# Altium schematic sheet PERIPHERAL.SchDoc
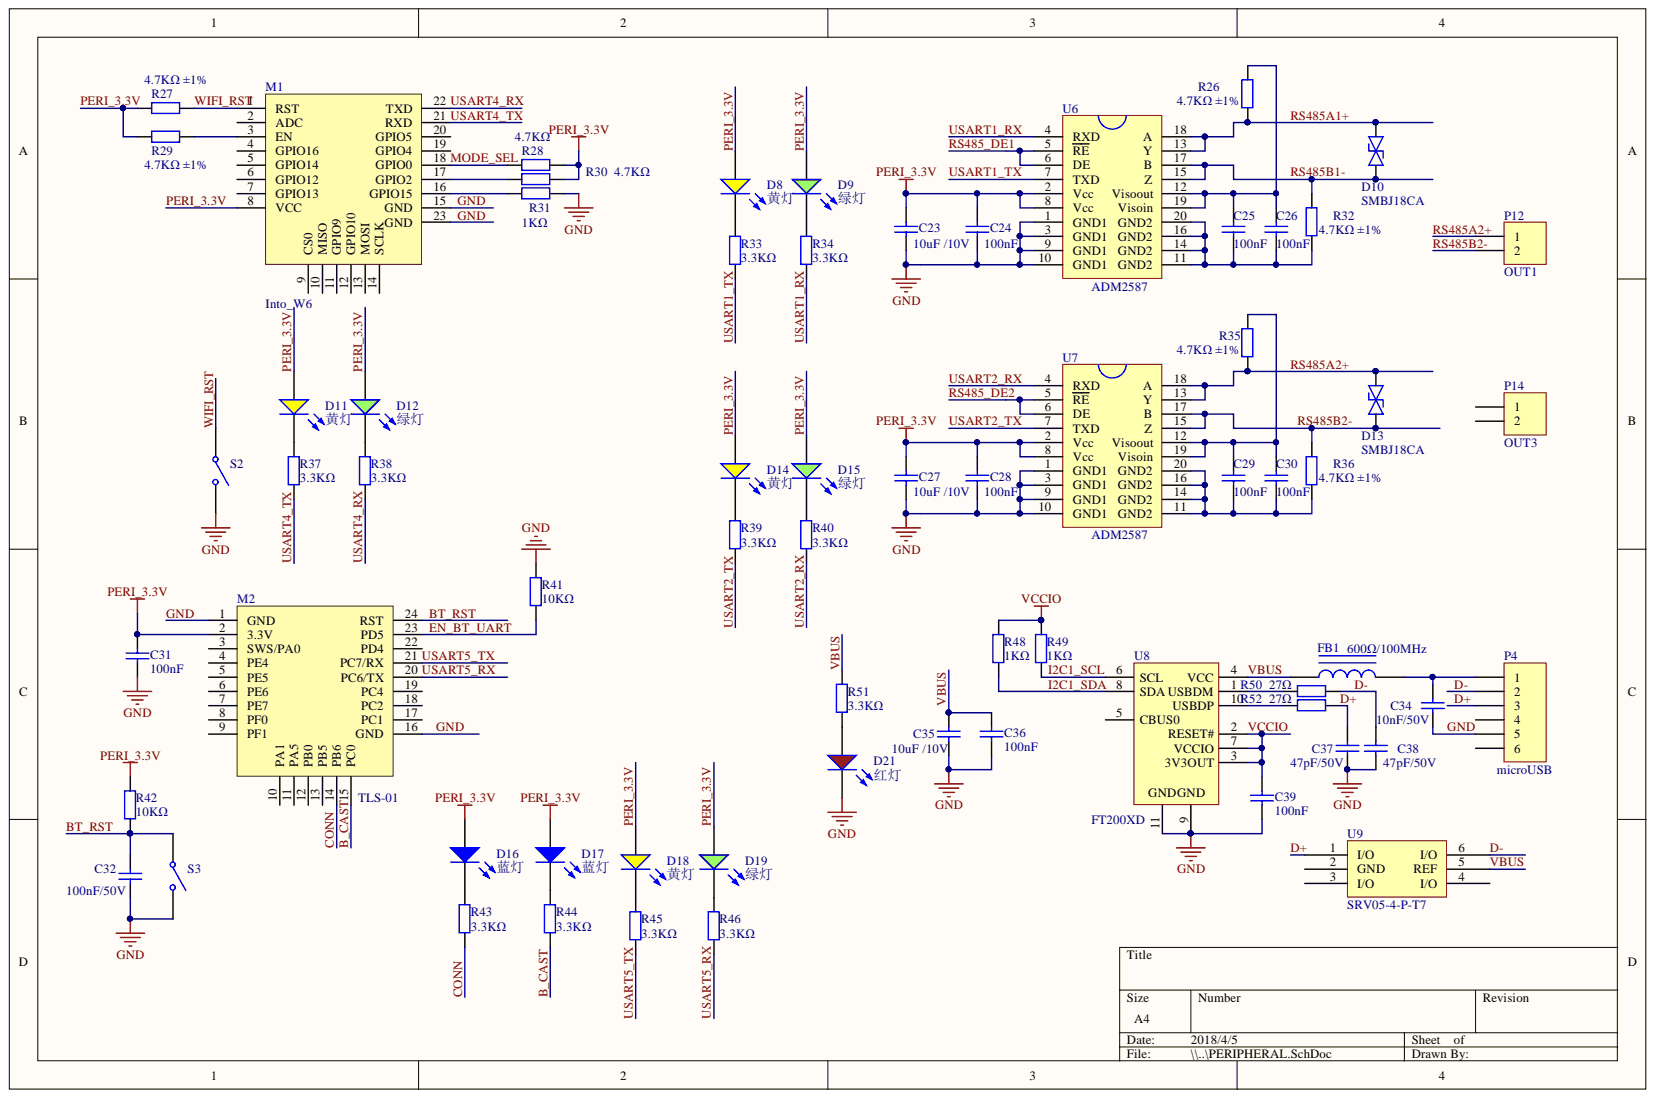
[diagram p0: PERIPHERAL.SchDoc]

## Parts
C23  10uF /10V  [0603_C]
C24  100nF  [0603_C]
C25  100nF  [0603_C]
C26  100nF  [0603_C]
C27  10uF /10V  [0603_C]
C28  100nF  [0603_C]
C29  100nF  [0603_C]
C30  100nF  [0603_C]
C31  100nF  [0603_C]
C32  100nF/50V  [0603_C]
C34  10nF/50V  [0603_C]
C35  10uF /10V  [0603_C]
C36  100nF  [0603_C]
C37  47pF/50V  [0603_C]
C38  47pF/50V  [0603_C]
C39  100nF  [0603_C]
D8  黄灯  [0603_LED_S1]
D9  绿灯  [0603_LED_S1]
D10  SMBJ18CA  [LC-SMB(DO-214AA)_S2]
D11  黄灯  [0603_LED_S1]
D12  绿灯  [0603_LED_S1]
D13  SMBJ18CA  [LC-SMB(DO-214AA)_S2]
D14  黄灯  [0603_LED_S1]
D15  绿灯  [0603_LED_S1]
D16  蓝灯  [0603_LED_S1]
D17  蓝灯  [0603_LED_S1]
D18  黄灯  [0603_LED_S1]
D19  绿灯  [0603_LED_S1]
D21  红灯  [0603_LED_S1]
FB1  600Ω/100MHz  [0805_L]
M1  Into_W6
M2  TLS-01  [TLS-01]
P4  microUSB  [MICRO-USB-LC]
P12  OUT1  [15EDG-3.81MM-2P]
P14  OUT3  [15EDG-3.81MM-2P]
R26  4.7KΩ ±1%  [0603_R]
R27  4.7KΩ ±1%  [0603_R]
R28  4.7KΩ  [0603_R]
R29  4.7KΩ ±1%  [0603_R]
R30  4.7KΩ  [0603_R]
R31  1KΩ  [0603_R]
R32  4.7KΩ ±1%  [0603_R]
R33  3.3KΩ  [0603_R]
R34  3.3KΩ  [0603_R]
R35  4.7KΩ ±1%  [0603_R]
R36  4.7KΩ ±1%  [0603_R]
R37  3.3KΩ  [0603_R]
R38  3.3KΩ  [0603_R]
R39  3.3KΩ  [0603_R]
R40  3.3KΩ  [0603_R]
R41  10KΩ  [0603_R]
R42  10KΩ  [0603_R]
R43  3.3KΩ  [0603_R]
R44  3.3KΩ  [0603_R]
R45  3.3KΩ  [0603_R]
R46  3.3KΩ  [0603_R]
R48  1KΩ  [0603_R]
R49  1KΩ  [0603_R]
R50  27Ω  [0603_R]
R51  3.3KΩ  [0603_R]
R52  27Ω  [0603_R]
S2  (no value)  [KEY_6X6]
S3  (no value)  [KEY_6X6]
U6  ADM2587  [LC-SOIC-20_300mil]
U7  ADM2587  [LC-SOIC-20_300mil]
U8  FT200XD  [DFN-10_3X3]
U9  SRV05-4-P-T7  [SOT-23-6]

## Nets
B_CAST: M2.15(PC0) R44.1
BT_RST: C32.2 M2.24(RST) R42.1 S3.1
CONN: M2.14(PB6) R43.1
D+: C37.2 P4.3 R52.2 U9.1(I/O)
D-: C38.2 P4.2 R50.2 U9.6(I/O)
EN_BT_UART: M2.23(PD5) R41.1
GND: C23.1 C24.1 C27.1 C28.1 C31.1 C32.1 C34.1 C35.1 C36.1 C37.1 C38.1 C39.1 D21.K M1.15(GND) M1.23(GND) M2.1(GND) M2.16(GND) P4.5 R31.2 R41.2 S2.2 S3.2 U6.1(GND1) U6.3(GND1) U6.9(GND1) U6.10(GND1) U7.1(GND1) U7.3(GND1) U7.9(GND1) U7.10(GND1) U8.9 U8.11
I2C1_SCL: R49.1 U8.6(SCL)
I2C1_SDA: R48.1 U8.8(SDA)
MODE_SEL: M1.18(GPIO0) R28.2
PERI_3.3V: C23.2 C24.2 C27.2 C28.2 C31.2 D8.A D9.A D11.A D12.A D14.A D15.A D16.A D17.A D18.A D19.A M1.8(VCC) M2.2(3.3V) R27.2 R28.1 R29.2 R30.1 R42.2 U6.2(Vcc) U6.8(Vcc) U7.2(Vcc) U7.8(Vcc)
RS485_DE1: U6.5(RE) U6.6(DE)
RS485_DE2: U7.5(RE) U7.6(DE)
RS485A1+: D10.2 R26.1 U6.13(Y) U6.18(A)
RS485A2+: D13.2 P12.1 R35.1 U7.13(Y) U7.18(A)
RS485B1-: D10.1 R32.2 U6.15(Z) U6.17(B)
RS485B2-: D13.1 P12.2 R36.2 U7.15(Z) U7.17(B)
USART1_RX: R34.1 U6.4(RXD)
USART1_TX: R33.1 U6.7(TXD)
USART2_RX: R40.1 U7.4(RXD)
USART2_TX: R39.1 U7.7(TXD)
USART4_RX: M1.22(TXD) R38.1
USART4_TX: M1.21(RXD) R37.1
USART5_RX: M2.20(PC6/TX) R46.1
USART5_TX: M2.21(PC7/RX) R45.1
VBUS: C35.2 C36.2 FB1.1 R51.2 U8.4(VCC) U9.5(REF)
VCCIO: C39.2 R48.2 R49.2 U8.2(RESET#) U8.3(3V3OUT) U8.7(VCCIO)
WIFI_RST: M1.1(RST) R27.1 S2.1
NetC25_1: C25.1 C26.1 R32.1 U6.11(GND2) U6.14(GND2) U6.16(GND2) U6.20(GND2)
NetC25_2: C25.2 C26.2 R26.2 U6.12(Visoout) U6.19(Visoin)
NetC29_1: C29.1 C30.1 R36.1 U7.11(GND2) U7.14(GND2) U7.16(GND2) U7.20(GND2)
NetC29_2: C29.2 C30.2 R35.2 U7.12(Visoout) U7.19(Visoin)
NetC34_2: C34.2 FB1.2 P4.1
NetD8_K: D8.K R33.2
NetD9_K: D9.K R34.2
NetD11_K: D11.K R37.2
NetD12_K: D12.K R38.2
NetD14_K: D14.K R39.2
NetD15_K: D15.K R40.2
NetD16_K: D16.K R43.2
NetD17_K: D17.K R44.2
NetD18_K: D18.K R45.2
NetD19_K: D19.K R46.2
NetD21_A: D21.A R51.1
NetM1_3: M1.3(EN) R29.1
NetM1_16: M1.16(GPIO15) R31.1
NetM1_17: M1.17(GPIO2) R30.2
NetR50_1: R50.1 U8.1(USBDM)
NetR52_1: R52.1 U8.10(USBDP)

Not connected: M1 2(ADC) 4(GPIO16) 5(GPIO14) 6(GPIO12) 7(GPIO13) 9(CS0) 10(MISO) 11(GPIO9) 12(GPIO10) 13(MOSI) 14(SCLK) 19(GPIO4) 20(GPIO5); M2 3(SWS/PA0) 4(PE4) 5(PE5) 6(PE6) 7(PE7) 8(PF0) 9(PF1) 10(PA1) 11(PA5) 12(PB0) 13(PB5) 17(PC1) 18(PC2) 19(PC4) 22(PD4); P4 4 6; P14 1 2; U8 5(CBUS0); U9 2(GND) 3(I/O) 4(I/O)

## Library data of the parts
C23 C27 C35 = 0603 10uF (106) 10% 10V: 贴片电容; Component Kind: Standard; ComponentLink1Description: 供应商链接; ComponentLink1URL: http://www.szlcsc.com/product/details_20411.html; Library Name: Miscellaneous Devices LC.IntLib; Package: 0603; Supplier: LC; SuppliersPartNumber: C19702
C24-C26 C28-C32 C36 C39 = 0603 100nF (104) 10% 50V: 贴片电容; Component Kind: Standard; ComponentLink1Description: 供应商链接; ComponentLink1URL: http://www.szlcsc.com/product/details_15331.html; Library Name: Miscellaneous Devices LC.IntLib; Package: 0603; Supplier: LC; SuppliersPartNumber: C14663
C34 = 0603 10nF (103) 10% 50V: 贴片电容; Component Kind: Standard; ComponentLink1Description: 供应商链接; ComponentLink1URL: http://www.szlcsc.com/product/details_58143.html; Library Name: Miscellaneous Devices LC.IntLib; Package: 0603; Supplier: LC; SuppliersPartNumber: C57112
C37 C38 = 0603 47pF (470) 5% 50V: 贴片电容; Component Kind: Standard; ComponentLink1Description: 供应商链接; ComponentLink1URL: http://www.szlcsc.com/product/details_2023.html; Library Name: Miscellaneous Devices LC.IntLib; Package: 0603; Supplier: LC; SuppliersPartNumber: C1671
D8 D11 D14 D18 = 0603 黄灯: 发光二极管; Component Kind: Standard; ComponentLink1Description: 供应商链接; ComponentLink1URL: http://www.szlcsc.com/product/details_73147.html; Library Name: Miscellaneous Devices LC.IntLib; Package: 0603; Supplier: LC; SuppliersPartNumber: C72038
D9 D12 D15 D19 = 0603 绿灯: 发光二极管; Component Kind: Standard; ComponentLink1Description: 供应商链接; ComponentLink1URL: http://www.szlcsc.com/product/details_73152.html; Library Name: Miscellaneous Devices LC.IntLib; Package: 0603; Supplier: LC; SuppliersPartNumber: C72043
D10 D13 = TVS: Component Kind: Standard
D16 D17 = 0603 蓝灯: 发光二极管; Component Kind: Standard; ComponentLink1Description: 供应商链接; ComponentLink1URL: http://www.szlcsc.com/product/details_73150.html; Library Name: Miscellaneous Devices LC.IntLib; Package: 0603; Supplier: LC; SuppliersPartNumber: C72041
D21 = 0603 红灯: 发光二极管; Component Kind: Standard; ComponentLink1Description: 供应商链接; ComponentLink1URL: http://www.szlcsc.com/product/details_2659.html; Library Name: Miscellaneous Devices LC.IntLib; Package: 0603; Supplier: LC; SuppliersPartNumber: C2286
FB1 = 0805 600Ω 25% 100MHz: 贴片磁珠; Component Kind: Standard; ComponentLink1Description: 供应商链接; ComponentLink1URL: http://www.szlcsc.com/product/details_1369.html; Library Name: Miscellaneous Devices LC.IntLib; Package: 0805; Supplier: LC; SuppliersPartNumber: C1017
P4 = Header 6: Header, 6-Pin; Component Kind: Standard; LatestRevisionDate: 17-Jul-2002; LatestRevisionNote: Re-released for DXP Platform.; Library Name: Miscellaneous Connectors.IntLib; Publisher: Altium Limited
P12 P14 = Header 2: Header, 2-Pin; Component Kind: Standard; LatestRevisionDate: 17-Jul-2002; LatestRevisionNote: Re-released for DXP Platform.; Library Name: Miscellaneous Connectors.IntLib; Publisher: Altium Limited
R26-R30 R32 R35 R36 = 0603 4.7KΩ (4701) 1%: 贴片电阻; Component Kind: Standard; ComponentLink1Description: 供应商链接; ComponentLink1URL: http://www.szlcsc.com/product/details_23889.html; Library Name: Miscellaneous Devices LC.IntLib; Package: 0603; Supplier: LC; SuppliersPartNumber: C23162
R31 R48 R49 = 0603 1KΩ (1001) 1%: 贴片电阻; Component Kind: Standard; ComponentLink1Description: 供应商链接; ComponentLink1URL: http://www.szlcsc.com/product/details_21904.html; Library Name: Miscellaneous Devices LC.IntLib; Package: 0603; Supplier: LC; SuppliersPartNumber: C21190
R33 R34 R37-R40 R43-R46 R51 = 0603 3.3KΩ (3301) 1%: 贴片电阻; Component Kind: Standard; ComponentLink1Description: 供应商链接; ComponentLink1URL: http://www.szlcsc.com/product/details_23705.html; Library Name: Miscellaneous Devices LC.IntLib; Package: 0603; Supplier: LC; SuppliersPartNumber: C22978
R41 R42 = 0603 10KΩ (1002) 1%: 贴片电阻; Component Kind: Standard; ComponentLink1Description: 供应商链接; ComponentLink1URL: http://www.szlcsc.com/product/details_26547.html; Library Name: Miscellaneous Devices LC.IntLib; Package: 0603; Supplier: LC; SuppliersPartNumber: C25804
R50 R52 = 0603 27Ω (27R0) 1%: 贴片电阻; Component Kind: Standard; ComponentLink1Description: 供应商链接; ComponentLink1URL: http://www.szlcsc.com/product/details_25933.html; Library Name: Miscellaneous Devices LC.IntLib; Package: 0603; Supplier: LC; SuppliersPartNumber: C25190
S2 S3 = SW-SPST: Single-Pole, Single-Throw Switch; Component Kind: Standard; LatestRevisionDate: 17-Jul-2002; LatestRevisionNote: Re-released for DXP Platform.; Library Name: Miscellaneous Devices.IntLib; PackageReference: SPST-2; Published: 24-Mar-1999; Publisher: Altium Limited
U8 = FT200XD: DC-DC芯片; Component Kind: Standard; ComponentLink1Description: 供应商链接; ComponentLink1URL: http://www.szlcsc.com/product/details_10396.html; Package: SOP-8_EP_150mil; Supplier: LC; SuppliersPartNumber: C9864; Library Name: LYL_lib.SCHLIB
U9 = SRV05-4-P-T7: TVS; Component Kind: Standard; ComponentLink1Description: 供应商链接; ComponentLink1URL: http://www.szlcsc.com/product/details_86537.html; Library Name: Miscellaneous Devices LC.IntLib; Package: SOT-23-6; Supplier: LC; SuppliersPartNumber: C85364
M1 = Into_W6: Component Kind: Standard; Library Name: LYL_lib.SCHLIB
M2 = TLS-01: Component Kind: Standard; Library Name: LYL_lib.SCHLIB
U6 U7 = ADM2587: Component Kind: Standard
